# Altium schematic sheet 09_6V-DC_B.SchDoc(09_6V-DC_B)
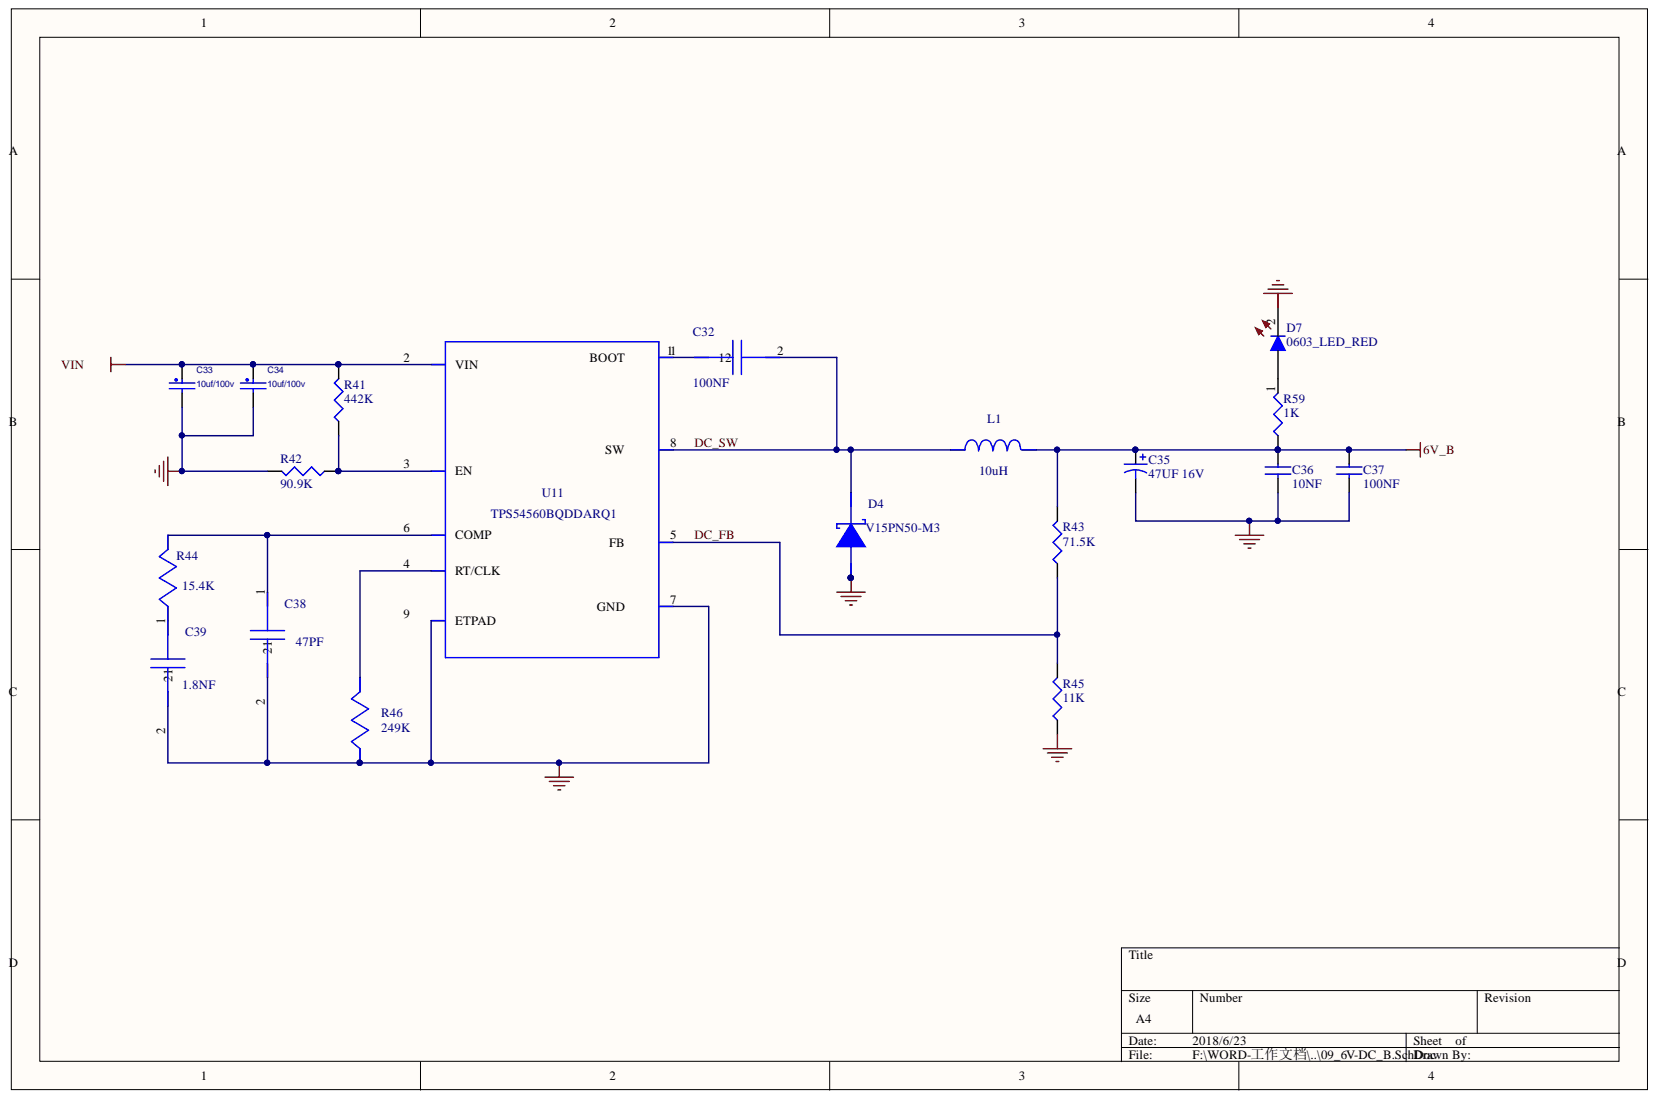
[diagram p0: 09_6V-DC_B.SchDoc]

## Parts
C32  100NF  [0402c]
C33  10uf/100v  [C2220]
C34  10uf/100v  [C2220]
C35  47UF 16V  [7343CAP]
C36  10NF  [0402C]
C37  100NF  [0402C]
C38  47PF  [0402c]
C39  1.8NF  [0402c]
D4  V15PN50-M3  [TO-277]
D7  0603_LED_RED  [0603-LED-RED]
L1  value 10uH  [10X10L]  (hidden comment: PCMB104T-100MS)
R41  442K  [R0402]
R42  90.9K  [R0402]
R43  71.5K  [R0402]
R44  15.4K  [0402R]
R45  11K  [R0402]
R46  249K  [0402R]
R59  1K  [R0402]
U11  TPS54560BQDDAR  [SO_P_8]

## Nets
6V_B: C35.A C36.1 C37.1 L1.2 R43.2 R59.1
GND: C33.2 C34.2 C35.C C36.2 C37.2 C38.2 C39.2 D4.A D7.2 R42.2 R45.1 R46.2 U11.7 U11.9(ETPAD)
VIN: C33.1 C34.1 R41.2 U11.2(VIN)
DC_FB: R43.1 R45.2 U11.5
DC_SW: C32.2 D4.K L1.1 U11.8
NetC32_1: C32.1 U11.1
NetC38_1: C38.1 R44.1 U11.6(COMP)
NetC39_1: C39.1 R44.2
NetD7_1: D7.1 R59.2
NetR41_1: R41.1 R42.1 U11.3(EN)
NetR46_1: R46.1 U11.4(RT/CLK)

## Library data of the parts
C32 C38 = GRM1555C1H9R1CA01D: GRM1555C1H9R1CA0; Manufacturer Name: MuRata; Component Kind: Standard; Library Name: TI WEBENCH; Manufacturer Part Number: GRM1555C1H9R1CA01D; PackageReference: GRM1555C1H9R1CA01D
  C32: Value: 100NF
C33 C34 = CAP_NP: Component Kind: Standard
C35 = Cap Pol1: T520V476M016ATE; Component Kind: Standard; LatestRevisionDate: 17-Jul-2002; LatestRevisionNote: Re-released for DXP Platform.; Library Name: Miscellaneous Devices.IntLib; PackageDescription: Polarized Capacitor (Radial); 2 Leads; PackageReference: RB7.6-15; Published: 8-Jun-2000; Signal Integrity: Cap Pol; Simulation: CAP; Publisher: Altium Limited
C36 C37 = C1005X5R1H104K: Component Kind: Standard; Library Name: Integrated_Library_RF_FEB_2012.IntLib
C39 = GRM3195C1H113JA01D: GRM3195C1H113JA0; Manufacturer Name: MuRata; Component Kind: Standard; Library Name: TI WEBENCH; Manufacturer Part Number: GRM3195C1H113JA01D; PackageReference: GRM3195C1H113JA01D
D4 = STPS20M100SG-TR: Manufacturer Name: STMicroelectronics; Component Kind: Standard; Library Name: TI WEBENCH; Manufacturer Part Number: STPS20M100SG-TR; PackageReference: STPS20M100SG-TR
L1 = SRP1270-100M: Manufacturer Name: Bourns; Component Kind: Standard; Description: SRP1270-100M; Library Name: TI WEBENCH; Manufacturer Part Number: SRP1270-100M; PackageReference: SRP1270-100M
R41-R43 R45 R59 = RC0402FR-0749R9L: Component Kind: Standard; Library Name: Integrated_Library_RF_FEB_2012.IntLib
R44 = CRCW0402274KFKED: Component Kind: Standard; Library Name: TI WEBENCH
R46 = CRCW0402274KFKED: Manufacturer Name: Vishay-Dale; Component Kind: Standard; Description: CRCW0402274KFKED; Library Name: TI WEBENCH; Manufacturer Part Number: CRCW0402274KFKED; PackageReference: CRCW0402274KFKED
U11 = TPS54560BQDDARQ1: Manufacturer Name: Texas Instruments; Component Kind: Standard; Description: TPS54560BQDDARQ1; Library Name: TI WEBENCH; Manufacturer Part Number: TPS54560BQDDARQ1; PackageReference: TPS54560BQDDARQ1; Value: Value
D7 = 0603_LED_RED: Component Kind: Standard; Description: 0603_LED_RED; Library Name: CUAV.SchLib
